# Altium schematic sheet 1_ADAPTER.SchDoc(1_ADAPTER)
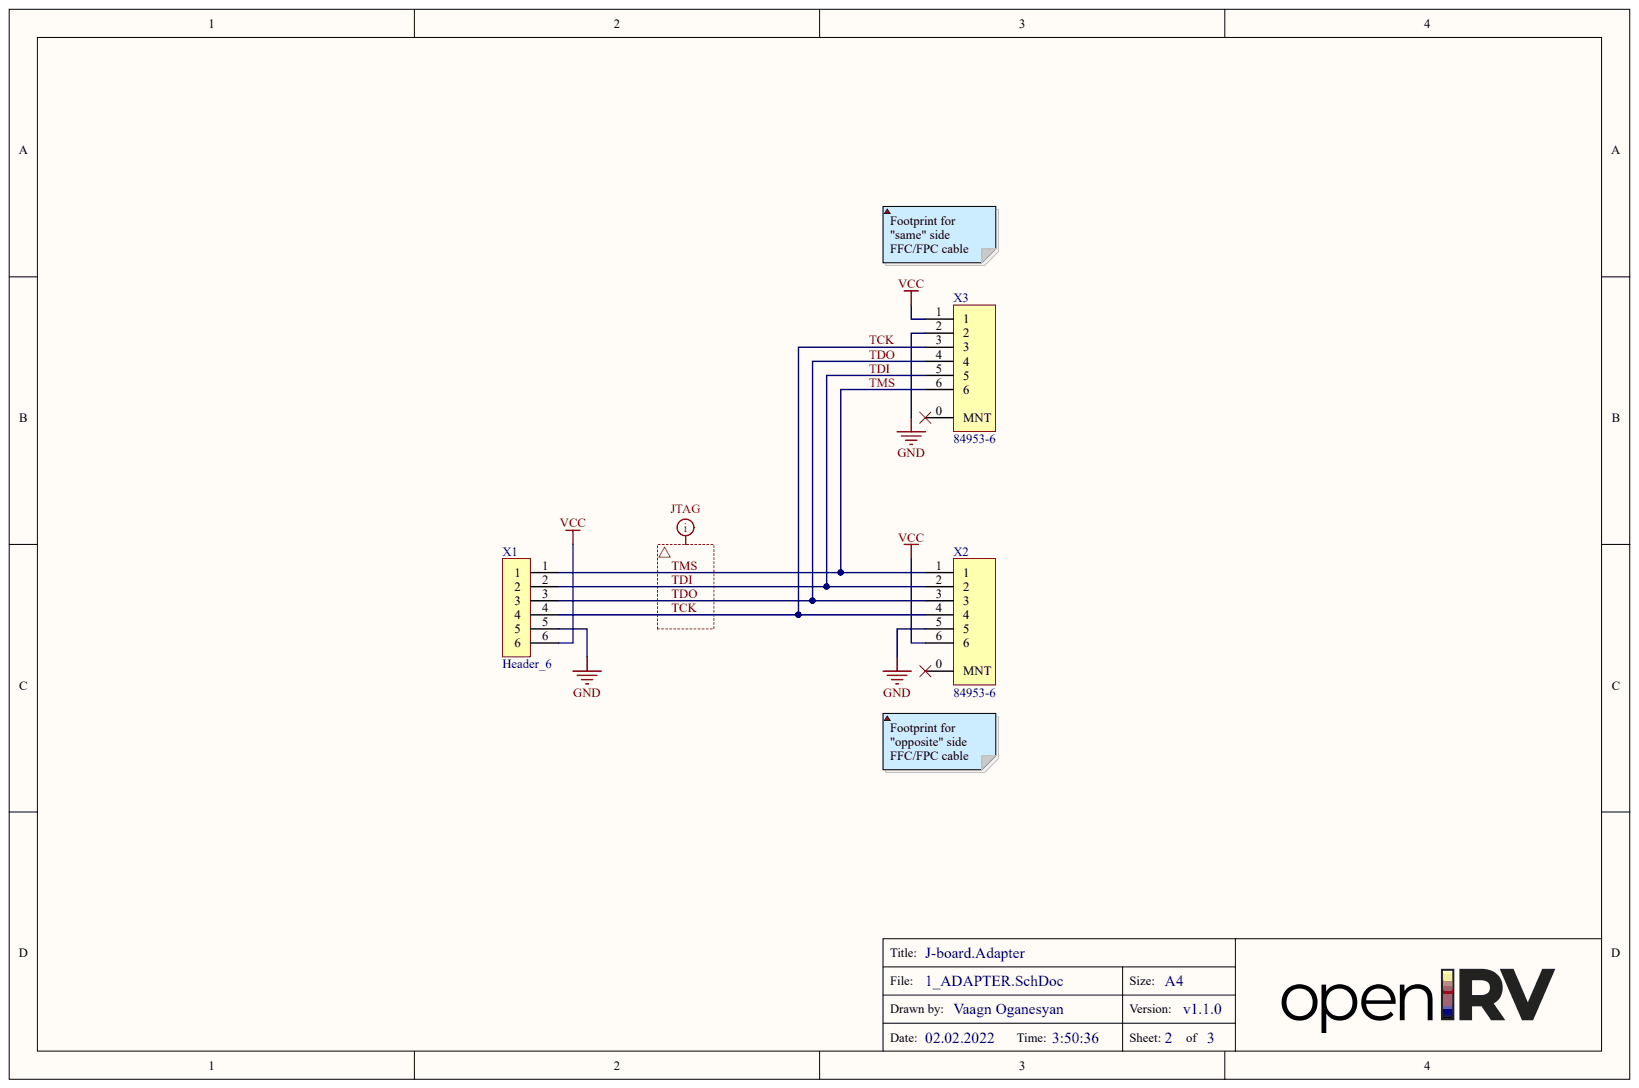
[diagram p0: 1_ADAPTER.SchDoc]

## Parts
X1  Header_6  [Header_6]
X2  84953-6  [84953-6]
X3  84953-6  [84953-6]

## Nets
GND: X1.5 X2.5 X3.2
VCC: X1.6 X2.6 X3.1
TCK: X1.4 X2.4 X3.3
TDI: X1.2 X2.2 X3.5
TDO: X1.3 X2.3 X3.4
TMS: X1.1 X2.1 X3.6
N00004:

Not connected: X2 0(MNT); X3 0(MNT)

## Library data of the parts
X1 = Header_6: Header 6 pin; Component Kind: Standard; Library Name: general.IntLib
X2 X3 = 84953-6: Component Kind: Standard; Library Name: general.IntLib
